# Altium schematic sheet charger.SchDoc(charger)
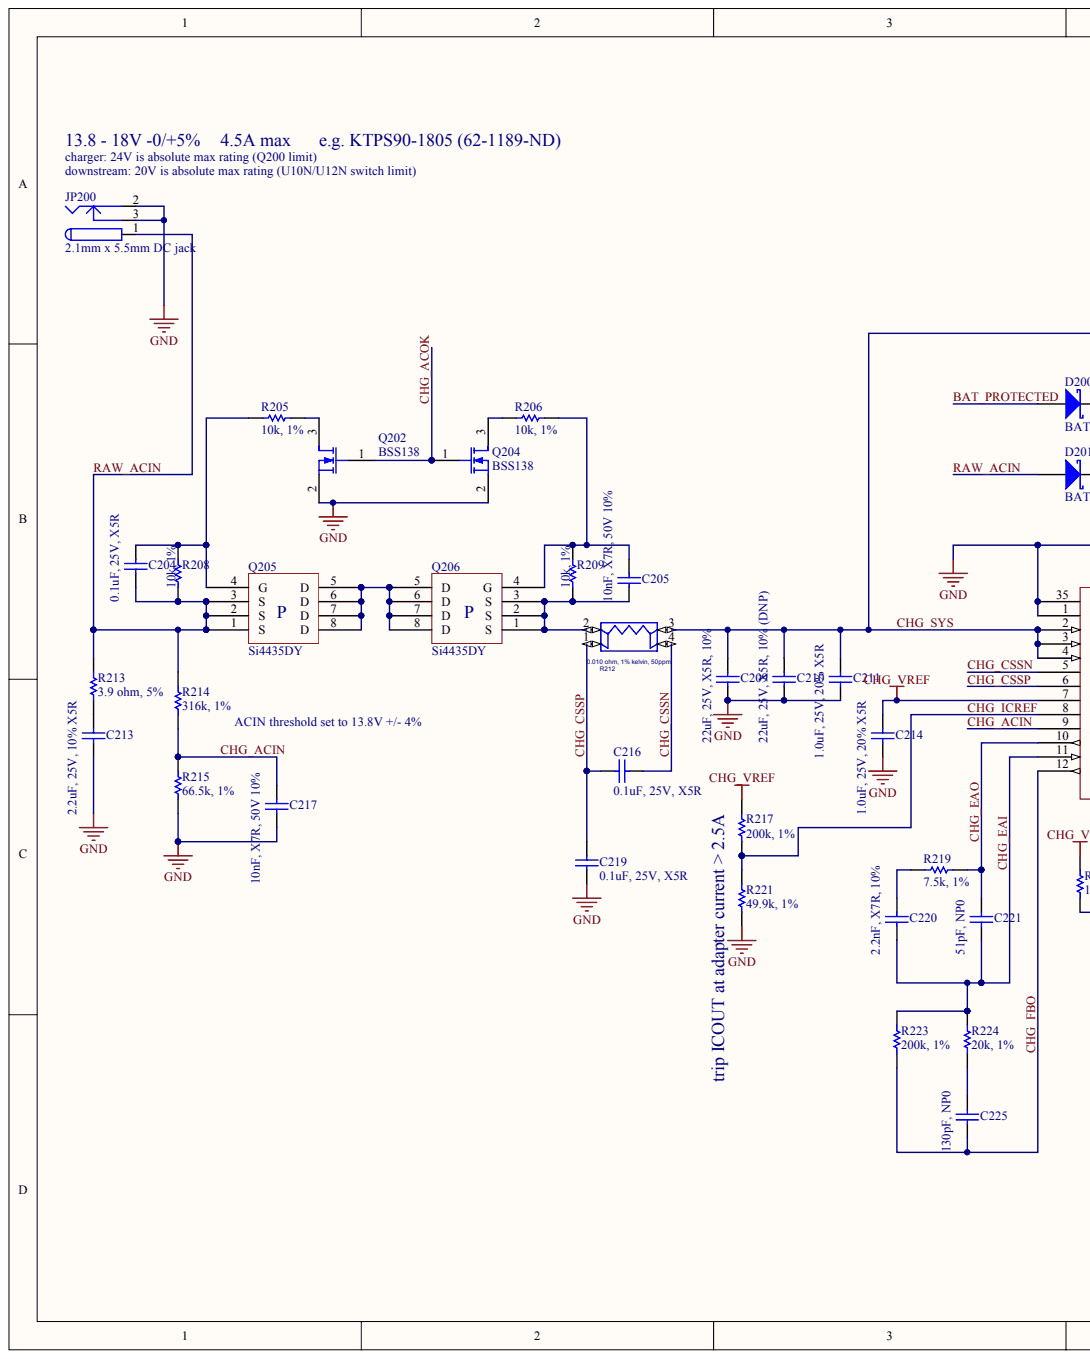
[diagram p0: charger.SchDoc, left]
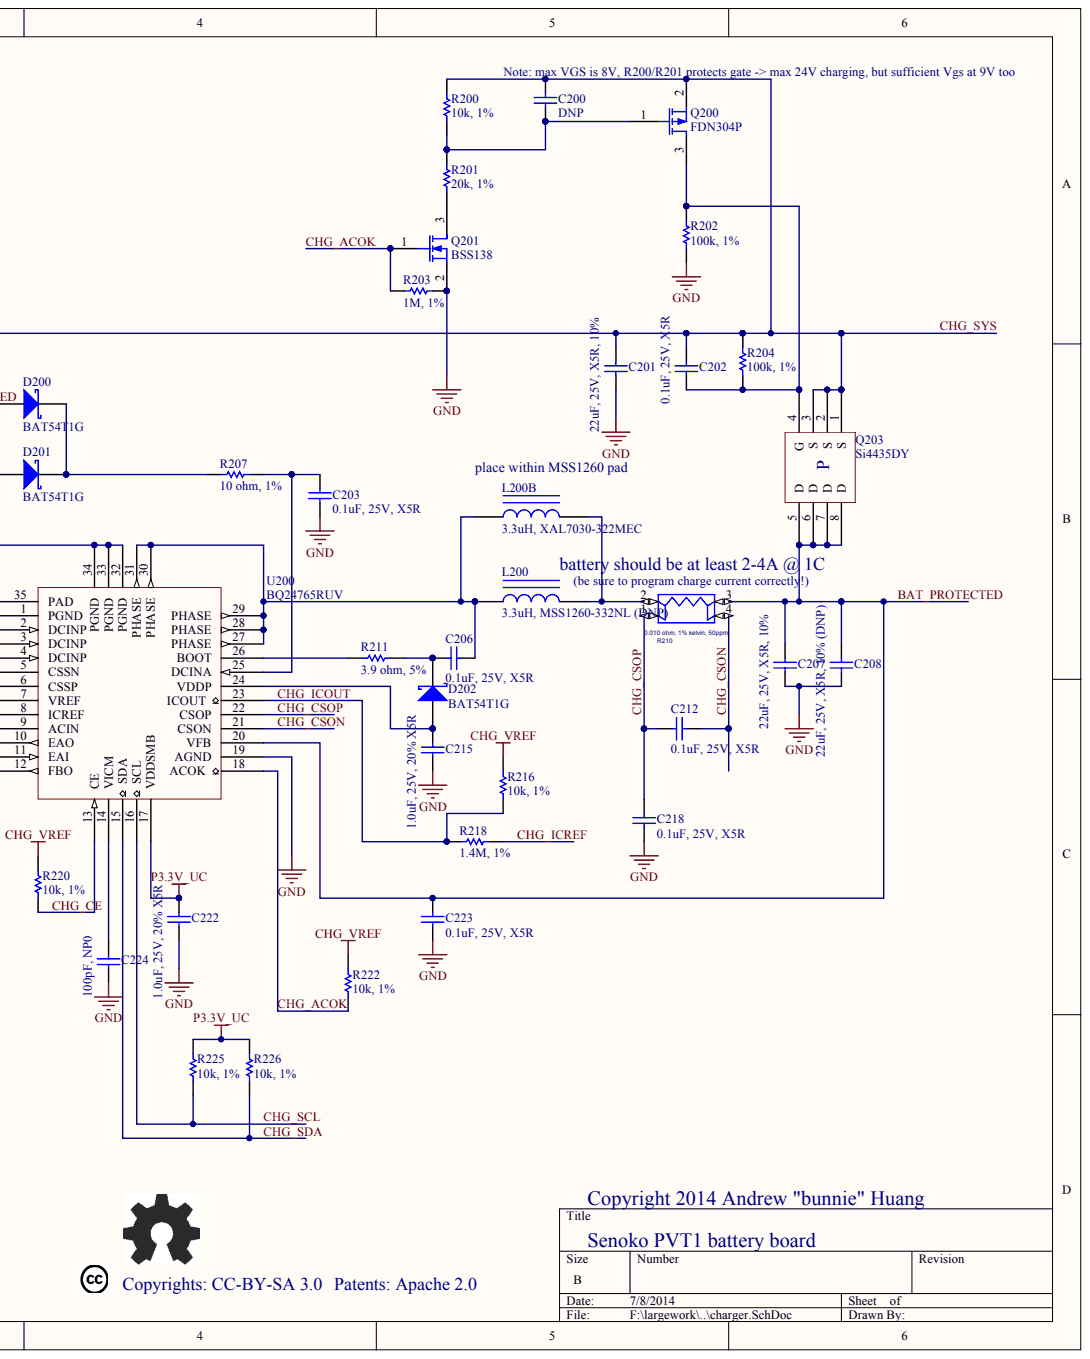
[diagram p1: charger.SchDoc, right]

## Parts
C200  DNP
C201  22uF, 25V, X5R, 10%
C202  0.1uF, 25V, X5R
C203  0.1uF, 25V, X5R
C204  0.1uF, 25V, X5R
C205  10nF, X7R, 50V 10%
C206  0.1uF, 25V, X5R
C207  22uF, 25V, X5R, 10%
C208  22uF, 25V, X5R, 10% (DNP)
C209  22uF, 25V, X5R, 10%
C210  22uF, 25V, X5R, 10% (DNP)
C211  1.0uF, 25V, 20% X5R
C212  0.1uF, 25V, X5R
C213  2.2uF, 25V, 10% X5R
C214  1.0uF, 25V, 20% X5R
C215  1.0uF, 25V, 20% X5R
C216  0.1uF, 25V, X5R
C217  10nF, X7R, 50V 10%
C218  0.1uF, 25V, X5R
C219  0.1uF, 25V, X5R
C220  2.2nF, X7R, 10%
C221  51pF, NP0
C222  1.0uF, 25V, 20% X5R
C223  0.1uF, 25V, X5R
C224  100pF, NP0
C225  130pF, NP0
D200  BAT54T1G
D201  BAT54T1G
D202  BAT54T1G
JP200  2.1mm x 5.5mm DC jack
L200  3.3uH, MSS1260-332NL (DNP)
L200B  3.3uH, XAL7030-322MEC
Q200  FDN304P
Q201  BSS138
Q202  BSS138
Q203  Si4435DY
Q204  BSS138
Q205  Si4435DY
Q206  Si4435DY
R200  10k, 1%
R201  20k, 1%
R202  100k, 1%
R203  1M, 1%
R204  100k, 1%
R205  10k, 1%
R206  10k, 1%
R207  10 ohm, 1%
R208  10k, 1%
R209  10k, 1%
R210  0.010 ohm, 1% kelvin, 50ppm  TOL: 1%
R211  3.9 ohm, 5%
R212  0.010 ohm, 1% kelvin, 50ppm  TOL: 1%
R213  3.9 ohm, 5%
R214  316k, 1%
R215  66.5k, 1%
R216  10k, 1%
R217  200k, 1%
R218  1.4M, 1%
R219  7.5k, 1%
R220  10k, 1%
R221  49.9k, 1%
R222  10k, 1%
R223  200k, 1%
R224  20k, 1%
R225  10k, 1%
R226  10k, 1%
U200  BQ24765RUV

## Nets
CHG_VREF: C214.1 R216.1 R217.1 R220.1 R222.1 U200.7(VREF)
GND: C201.2 C203.2 C207.2 C208.2 C209.2 C210.2 C211.2 C213.2 C214.2 C215.2 C217.2 C218.1 C219.2 C222.2 C223.1 C224.2 JP200.2 JP200.3 Q201.2 Q202.2 Q204.2 R202.2 R203.1 R215.2 R221.2 U200.1(PGND) U200.19(AGND) U200.32(PGND) U200.33(PGND) U200.34(PGND) U200.35(PAD)
P3.3V_UC: C222.1 R225.1 R226.1 U200.17(VDDSMB)
BAT_PROTECTED: C207.1 C208.1 C223.2 D200.1 Q203.5(D) Q203.6(D) Q203.7(D) Q203.8(D) R210.3 U200.20(VFB)
CHG_ACIN: C217.1 R214.2 R215.1 U200.9(ACIN)
CHG_ACOK: Q201.1 Q202.1 Q204.1 R203.2 R222.2 U200.18(ACOK)
CHG_CE: R220.2 U200.13(CE)
CHG_CSON: C212.1 R210.4 U200.21(CSON)
CHG_CSOP: C212.2 C218.2 R210.1 U200.22(CSOP)
CHG_CSSN: C216.2 R212.4 U200.5(CSSN)
CHG_CSSP: C216.1 C219.1 R212.1 U200.6(CSSP)
CHG_EAI: C220.2 C221.2 R223.1 R224.1 U200.11(EAI)
CHG_EAO: C221.1 R219.2 U200.10(EAO)
CHG_FBO: C225.2 R223.2 U200.12(FBO)
CHG_ICOUT: R216.2 R218.2 U200.23(ICOUT)
CHG_ICREF: R217.2 R218.1 R221.1 U200.8(ICREF)
CHG_SCL: R225.2 U200.16(SCL)
CHG_SDA: R226.2 U200.15(SDA)
CHG_SYS: C200.2 C201.1 C202.1 C209.1 C210.1 C211.1 Q200.2 Q203.1(S) Q203.2(S) Q203.3(S) R200.1 R204.1 R212.3 U200.2(DCINP) U200.3(DCINP) U200.4(DCINP)
RAW_ACIN: C204.2 D201.1 JP200.1 Q205.1(S) Q205.2(S) Q205.3(S) R208.1 R213.1 R214.1
NetC200_1: C200.1 Q200.1 R200.2 R201.1
NetC202_2: C202.2 Q200.3 Q203.4(G) R202.1 R204.2
NetC203_1: C203.1 R207.2 U200.25(DCINA)
NetC204_1: C204.1 Q205.4(G) R205.1 R208.2
NetC205_1: C205.1 Q206.4(G) R206.2 R209.2
NetC205_2: C205.2 Q206.1(S) Q206.2(S) Q206.3(S) R209.1 R212.2
NetC206_1: C206.1 D202.2 R211.2
NetC206_2: C206.2 L200.1 L200B.1 U200.27(PHASE) U200.28(PHASE) U200.29(PHASE) U200.30(PHASE) U200.31(PHASE)
NetC213_1: C213.1 R213.2
NetC215_1: C215.1 D202.1 U200.24(VDDP)
NetC220_1: C220.1 R219.1
NetC224_1: C224.1 U200.14(VICM)
NetC225_1: C225.1 R224.2
NetD200_2: D200.2 D201.2 R207.1
NetL200_2: L200.2 L200B.2 R210.2
NetQ201_3: Q201.3 R201.2
NetQ202_3: Q202.3 R205.2
NetQ204_3: Q204.3 R206.1
NetQ205_5: Q205.5(D) Q205.6(D) Q205.7(D) Q205.8(D) Q206.5(D) Q206.6(D) Q206.7(D) Q206.8(D)
NetR211_1: R211.1 U200.26(BOOT)

## Library data of the parts
D200-D202 = (no symbol name): Code - JEDEC: DO-214-AA; Published: 8-Jun-2000; Revision: July-2002: Re-released for DXP Platform.; Publisher: Altium Limited
R200 R201 R203 R205-R209 R211 R213-R226 = (no symbol name): Value: 100k; Published: 8-Jun-2000; LatestRevisionDate: 17-Jul-2002; LatestRevisionNote: Re-released for DXP Platform.; PackageReference: AXIAL-0.3; Publisher: Altium Limited
R210 R212 = (no symbol name): PART_NUMBER: R00034-001; CDS_LMAN_SYM_OUTLINE: -100,25,100,-75; NEEDS_NO_SIZE: TRUE; PATH: I224; PN: #; OriginalSymbolOrigin: (-100,50); LOCATION: RN32; SEC: 1; XY: (-5650,2000); WATTAGE: 1/2W; PHYS_PAGE: 12; PACK_TYPE: RES1206_4T; MFG_PN: LVK12R020FER; MFG: OHMITE; DRAWING: @BROWNSTONE_LIB.BROWNSTONE(SCH_1):PAGE12; CDS_LIB: resistors; MATERIAL: CH; TOLERANCE: ?; VER: 1; ROT: 1; CDS_SEC: 1; CDS_LOCATION: RN32; $TOLERANCE: ?; $SEC: 1; $PN: #; $LOCATION: RN32
C200-C225 JP200 L200 L200B Q200-Q206 R202 R204 U200 = (no symbol name)
  C200-C225: Published: 8-Jun-2000; LatestRevisionDate: 17-Jul-2002; LatestRevisionNote: Re-released for DXP Platform.; PackageReference: C1206
  JP200: Published: 17-Dec-2003; Datasheet: Latest Revision: 09-May-2002
  L200 L200B: Published: 24-Mar-1999; LatestRevisionDate: 17-Jul-2002; LatestRevisionNote: Re-released for DXP Platform.; PackageReference: AXIAL-0.9
  Q200: Published: 8-Jun-2000; LatestRevisionDate: 17-Jul-2002; LatestRevisionNote: Re-released for DXP Platform.; PackageVersion: 1995/1996; PackageReference: E3; PackageDescription: TO, Flat Index; 3 In-Line, Axial Leads; Body Dia. 4.6mm; Lead Dia. 0.5 mm (max)
  Q201 Q202 Q204: Published: 8-Jun-2000; LatestRevisionDate: 17-Jul-2002; LatestRevisionNote: Re-released for DXP Platform.; PackageVersion: 1995/1996; PackageReference: E3
  R202 R204: Published: 8-Jun-2000; LatestRevisionDate: 17-Jul-2002; LatestRevisionNote: Re-released for DXP Platform.; PackageReference: AXIAL-0.3; Value: 100k
  C200-C225 JP200 L200 L200B Q200-Q202 Q204 R202 R204: Publisher: Altium Limited
